# Altium schematic sheet 50SW_SCH_2.SchDoc
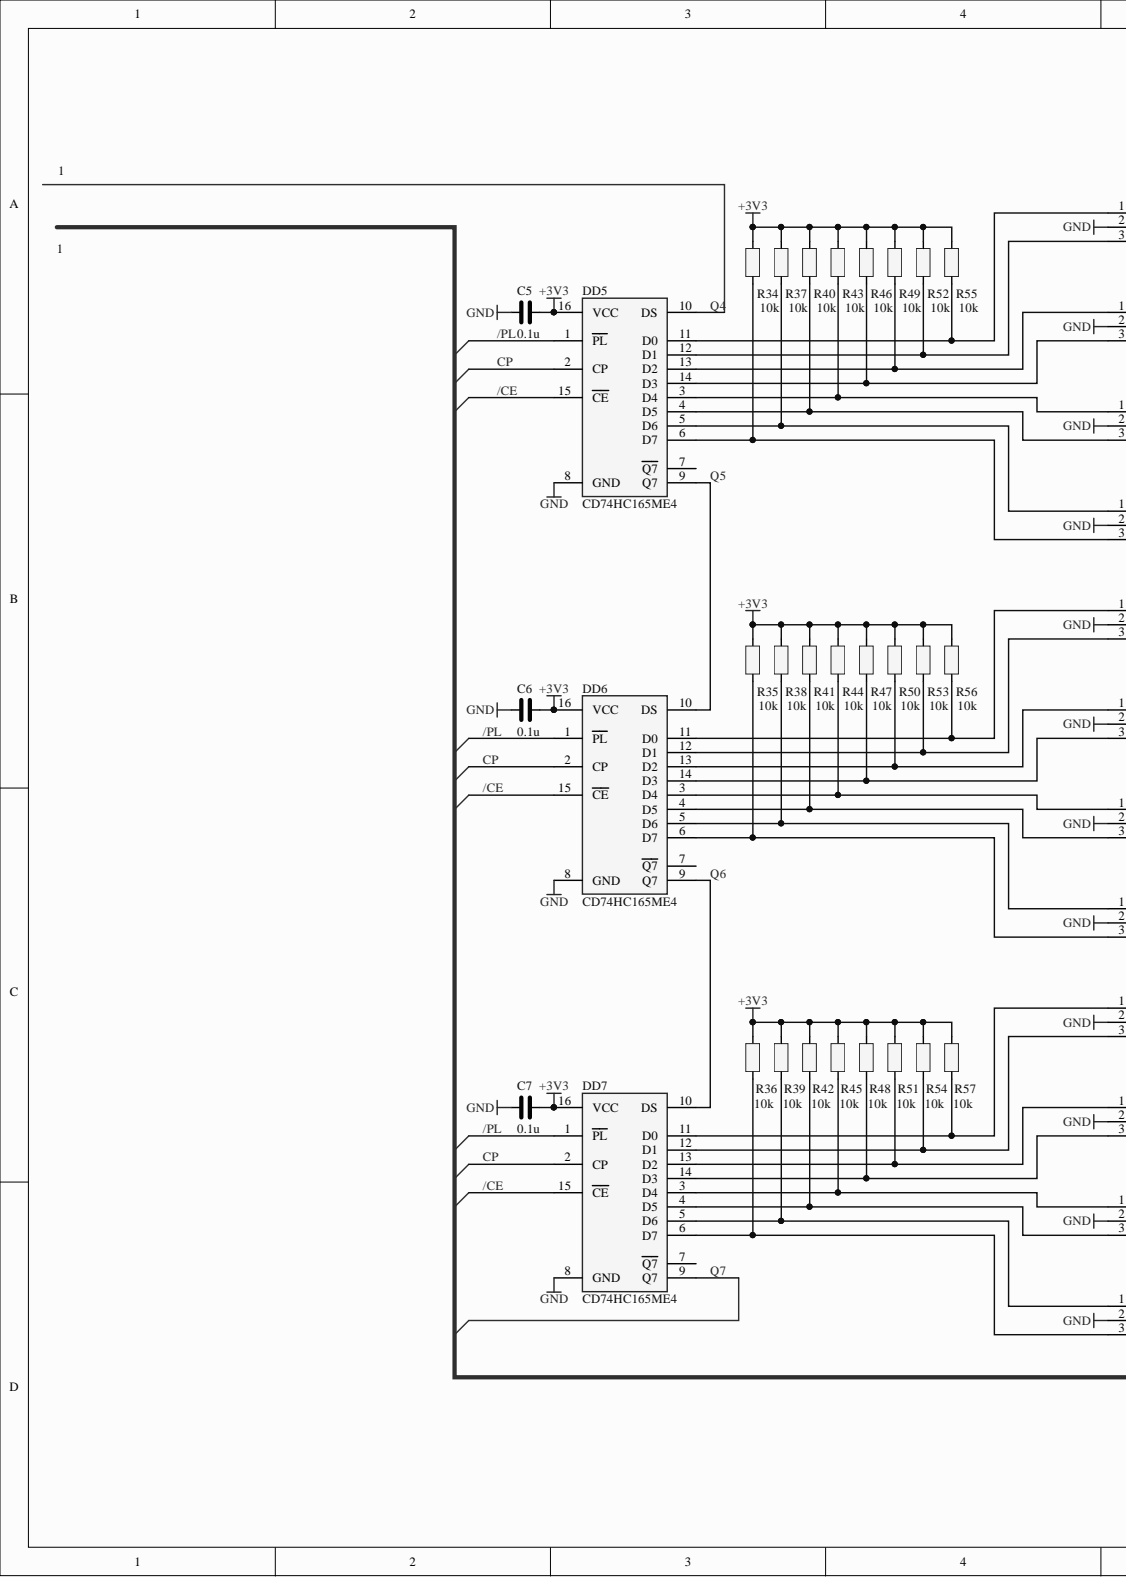
[diagram p0: 50SW_SCH_2.SchDoc, left]
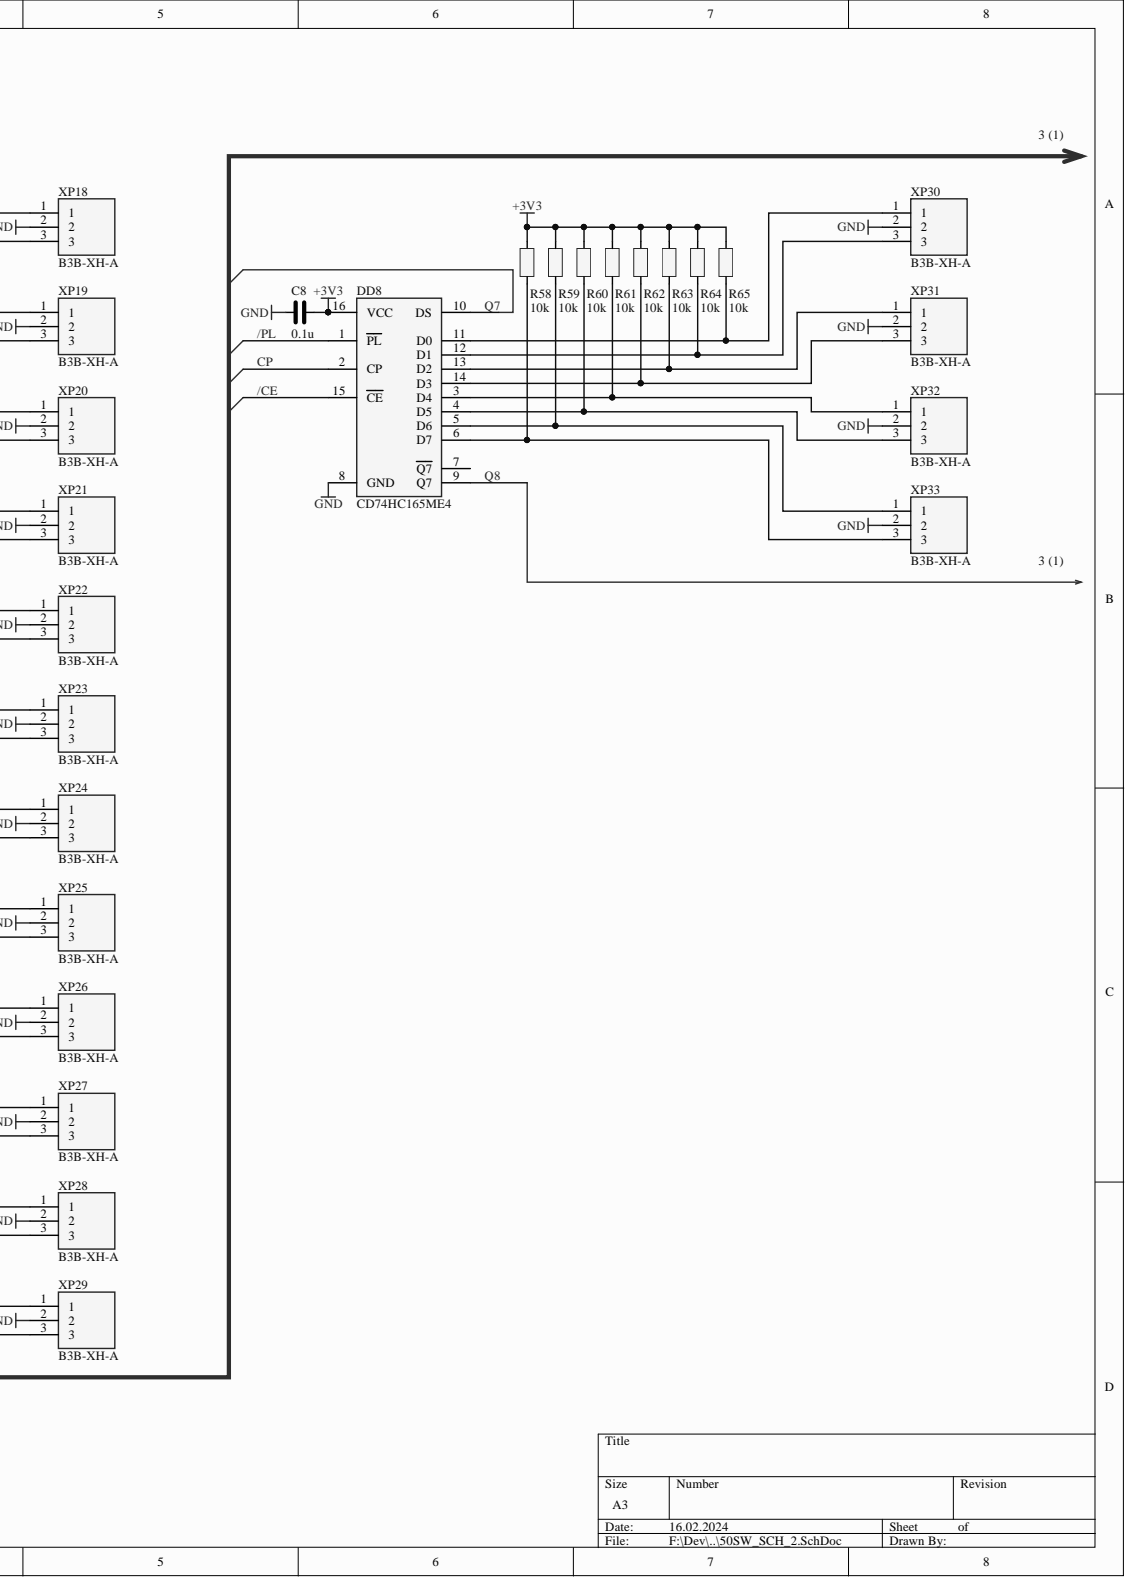
[diagram p1: 50SW_SCH_2.SchDoc, right]

## Parts
C5  0.1u  [C0603]
C6  0.1u  [C0603]
C7  0.1u  [C0603]
C8  0.1u  [C0603]
DD5  CD74HC165ME4  [SOIC127P600X175-16N]
DD6  CD74HC165ME4  [SOIC127P600X175-16N]
DD7  CD74HC165ME4  [SOIC127P600X175-16N]
DD8  CD74HC165ME4  [SOIC127P600X175-16N]
R34  10k  [R0603]
R35  10k  [R0603]
R36  10k  [R0603]
R37  10k  [R0603]
R38  10k  [R0603]
R39  10k  [R0603]
R40  10k  [R0603]
R41  10k  [R0603]
R42  10k  [R0603]
R43  10k  [R0603]
R44  10k  [R0603]
R45  10k  [R0603]
R46  10k  [R0603]
R47  10k  [R0603]
R48  10k  [R0603]
R49  10k  [R0603]
R50  10k  [R0603]
R51  10k  [R0603]
R52  10k  [R0603]
R53  10k  [R0603]
R54  10k  [R0603]
R55  10k  [R0603]
R56  10k  [R0603]
R57  10k  [R0603]
R58  10k  [R0603]
R59  10k  [R0603]
R60  10k  [R0603]
R61  10k  [R0603]
R62  10k  [R0603]
R63  10k  [R0603]
R64  10k  [R0603]
R65  10k  [R0603]
XP18  B3B-XH-A  [SHDR3W64P0X250_1X3_990X575X700P]
XP19  B3B-XH-A  [SHDR3W64P0X250_1X3_990X575X700P]
XP20  B3B-XH-A  [SHDR3W64P0X250_1X3_990X575X700P]
XP21  B3B-XH-A  [SHDR3W64P0X250_1X3_990X575X700P]
XP22  B3B-XH-A  [SHDR3W64P0X250_1X3_990X575X700P]
XP23  B3B-XH-A  [SHDR3W64P0X250_1X3_990X575X700P]
XP24  B3B-XH-A  [SHDR3W64P0X250_1X3_990X575X700P]
XP25  B3B-XH-A  [SHDR3W64P0X250_1X3_990X575X700P]
XP26  B3B-XH-A  [SHDR3W64P0X250_1X3_990X575X700P]
XP27  B3B-XH-A  [SHDR3W64P0X250_1X3_990X575X700P]
XP28  B3B-XH-A  [SHDR3W64P0X250_1X3_990X575X700P]
XP29  B3B-XH-A  [SHDR3W64P0X250_1X3_990X575X700P]
XP30  B3B-XH-A  [SHDR3W64P0X250_1X3_990X575X700P]
XP31  B3B-XH-A  [SHDR3W64P0X250_1X3_990X575X700P]
XP32  B3B-XH-A  [SHDR3W64P0X250_1X3_990X575X700P]
XP33  B3B-XH-A  [SHDR3W64P0X250_1X3_990X575X700P]

## Nets
+3V3: C5.1 C6.1 C7.1 C8.1 DD5.16(VCC) DD6.16(VCC) DD7.16(VCC) DD8.16(VCC) R34.1 R35.1 R36.1 R37.1 R38.1 R39.1 R40.1 R41.1 R42.1 R43.1 R44.1 R45.1 R46.1 R47.1 R48.1 R49.1 R50.1 R51.1 R52.1 R53.1 R54.1 R55.1 R56.1 R57.1 R58.1 R59.1 R60.1 R61.1 R62.1 R63.1 R64.1 R65.1
GND: C5.2 C6.2 C7.2 C8.2 DD5.8(GND) DD6.8(GND) DD7.8(GND) DD8.8(GND) XP18.2 XP19.2 XP20.2 XP21.2 XP22.2 XP23.2 XP24.2 XP25.2 XP26.2 XP27.2 XP28.2 XP29.2 XP30.2 XP31.2 XP32.2 XP33.2
/CE: DD5.15(CE) DD6.15(CE) DD7.15(CE) DD8.15(CE)
/PL: DD5.1(PL) DD6.1(PL) DD7.1(PL) DD8.1(PL)
CP: DD5.2(CP) DD6.2(CP) DD7.2(CP) DD8.2(CP)
Q4: DD5.10(DS)
Q5: DD5.9(Q7) DD6.10(DS)
Q6: DD6.9(Q7) DD7.10(DS)
Q7: DD7.9(Q7) DD8.10(DS)
Q8: DD8.9(Q7)
NetDD5_3: DD5.3(D4) R43.2 XP20.1
NetDD5_4: DD5.4(D5) R40.2 XP20.3
NetDD5_5: DD5.5(D6) R37.2 XP21.1
NetDD5_6: DD5.6(D7) R34.2 XP21.3
NetDD5_11: DD5.11(D0) R55.2 XP18.1
NetDD5_12: DD5.12(D1) R52.2 XP18.3
NetDD5_13: DD5.13(D2) R49.2 XP19.1
NetDD5_14: DD5.14(D3) R46.2 XP19.3
NetDD6_3: DD6.3(D4) R44.2 XP24.1
NetDD6_4: DD6.4(D5) R41.2 XP24.3
NetDD6_5: DD6.5(D6) R38.2 XP25.1
NetDD6_6: DD6.6(D7) R35.2 XP25.3
NetDD6_11: DD6.11(D0) R56.2 XP22.1
NetDD6_12: DD6.12(D1) R53.2 XP22.3
NetDD6_13: DD6.13(D2) R50.2 XP23.1
NetDD6_14: DD6.14(D3) R47.2 XP23.3
NetDD7_3: DD7.3(D4) R45.2 XP28.1
NetDD7_4: DD7.4(D5) R42.2 XP28.3
NetDD7_5: DD7.5(D6) R39.2 XP29.1
NetDD7_6: DD7.6(D7) R36.2 XP29.3
NetDD7_11: DD7.11(D0) R57.2 XP26.1
NetDD7_12: DD7.12(D1) R54.2 XP26.3
NetDD7_13: DD7.13(D2) R51.2 XP27.1
NetDD7_14: DD7.14(D3) R48.2 XP27.3
NetDD8_3: DD8.3(D4) R61.2 XP32.1
NetDD8_4: DD8.4(D5) R60.2 XP32.3
NetDD8_5: DD8.5(D6) R59.2 XP33.1
NetDD8_6: DD8.6(D7) R58.2 XP33.3
NetDD8_11: DD8.11(D0) R65.2 XP30.1
NetDD8_12: DD8.12(D1) R64.2 XP30.3
NetDD8_13: DD8.13(D2) R63.2 XP31.1
NetDD8_14: DD8.14(D3) R62.2 XP31.3

Not connected: DD5 7(Q7); DD6 7(Q7); DD7 7(Q7); DD8 7(Q7)

## Library data of the parts
C5-C8 = C0603: BOMVal: 0603-X7R-16 В-0.1 мкФ ±10% керамический; Dielectric: X7R; Scale: u; ScaleR: мк; SizeImp: 0603; Tolerance: 10; TypeR: керамический; Value: 0.1; Voltage: 16; Component Kind: Standard; Library Name: passive.SchLib
DD5-DD8 = CD74HC165ME4: Integrated Circuit; Manufacturer_Name: Texas Instruments; BOMVal: Микросхема  CD74HC165ME4; NameR: Микросхема; Component Kind: Standard; Datasheet Link: https://www.ti.com/lit/gpn/cd74hc165; Height: 1.75mm; Library Name: SamacSys.SchLib; PartNumber: CD74HC165ME4
R34-R65 = R0603: BOMVal: 0603-0.1 Вт-10 кОмОм±5%; Power: 0.1; Scale: k; ScaleR: кОм; SizeImp: 0603; Tolerance: 5; Value: 10; Component Kind: Standard; Library Name: passive.SchLib
XP18-XP33 = B3B-XH-A: Connector; Manufacturer_Name: JST (JAPAN SOLDERLESS TERMINALS); BOMVal: Вилка на плату B3B-XH-A JST (JAPAN SOLDERLESS TERMINALS); NameR: Вилка; TypeR: на плату; Component Kind: Standard; Datasheet Link: http://www.jst-mfg.com/product/pdf/eng/eXH.pdf; Height: 7mm; Library Name: SamacSys_By.SchLib; PartNumber: B3B-XH-A
